# Altium schematic sheet usb_uart.SchDoc(usb_uart)
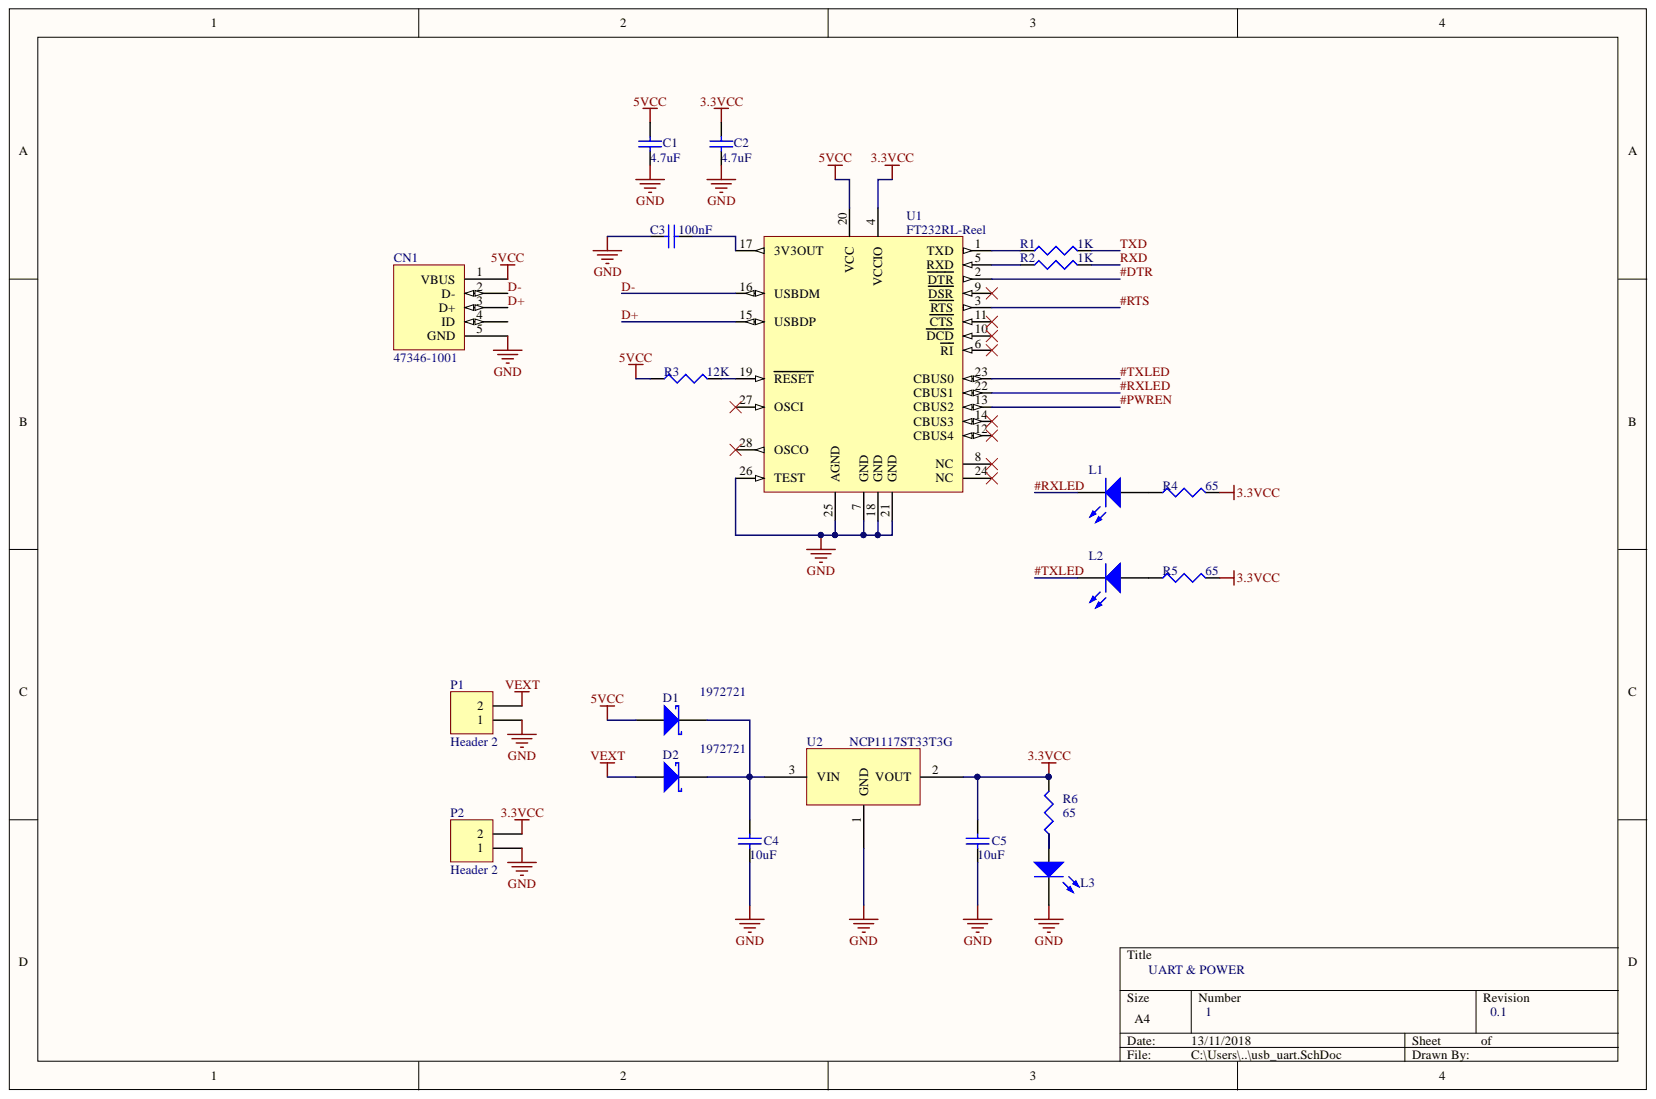
[diagram p0: usb_uart.SchDoc]

## Parts
C1  value 4.7uF  [C1206]  (hidden comment: Cap Semi)
C2  value 4.7uF  [C1206]  (hidden comment: Cap Semi)
C3  value 100nF  [C1206]  (hidden comment: Cap Semi)
C4  value 10uF  [C1206]  (hidden comment: Cap Semi)
C5  value 10uF  [C1206]  (hidden comment: Cap Semi)
CN1  47346-1001  [47346-1001]
D1  Supplier Part Number 1: 1972721  [SOD-128]  (hidden comment: PMEG3020DEP,115)
D2  Supplier Part Number 1: 1972721  [SOD-128]  (hidden comment: PMEG3020DEP,115)
L1  KM-23  [SOT-23]  (value not drawn)
L2  KM-23  [SOT-23]  (value not drawn)
L3  KM-23  [SOT-23]  (value not drawn)
P1  Header 2  [HDR1X2]
P2  Header 2  [HDR1X2]
R1  value 1K  [J1-0603]  (hidden comment: Res3)
R2  value 1K  [J1-0603]  (hidden comment: Res3)
R3  value 12K  [J1-0603]  (hidden comment: Res3)
R4  value 65  [J1-0603]  (hidden comment: Res3)
R5  value 65  [J1-0603]  (hidden comment: Res3)
R6  value 65  [J1-0603]  (hidden comment: Res3)
U1  FT232RL-Reel  [SSOP-28_N]
U2  NCP1117ST33T3G  [SOT-223-3]

## Nets
3.3VCC: C2.2 C5.1 P2.2 R4.2 R5.2 R6.2 U1.4(VCCIO) U2.2
5VCC: C1.2 CN1.1(VBUS) D1.2 R3.1 U1.20(VCC)
GND: C1.1 C2.1 C3.1 C4.1 C5.2 CN1.5(GND) L3.3 P1.1 P2.1 U1.7(GND) U1.18(GND) U1.21(GND) U1.25(AGND) U1.26(TEST) U2.1
VEXT: D2.2 P1.2
#DTR: U1.2(DTR)
#PWREN: U1.13(CBUS2)
#RTS: U1.3(RTS)
#RXLED: L1.3 U1.22(CBUS1)
#TXLED: L2.3 U1.23(CBUS0)
D+: CN1.3(D+) U1.15(USBDP)
D-: CN1.2(D-) U1.16(USBDM)
RXD: R2.2
TXD: R1.2
NetC3_2: C3.2 U1.17(3V3OUT)
NetC4_2: C4.2 D1.1 D2.1 U2.3
NetL1_1: L1.1 R4.1
NetL2_1: L2.1 R5.1
NetL3_1: L3.1 R6.1
NetR1_1: R1.1 U1.1(TXD)
NetR2_1: R2.1 U1.5(RXD)
NetR3_2: R3.2 U1.19(RESET)

Not connected: CN1 4(ID); U1 6(RI) 8(NC) 9(DSR) 10(DCD) 11(CTS) 12(CBUS4) 14(CBUS3) 24(NC) 27(OSCI) 28(OSCO)

## Library data of the parts
C1-C5 = Cap Semi: Capacitor (Semiconductor SIM Model); Simulation: CAP; Signal Integrity: Cap Semi; Published: 8-Jun-2000; PackageReference: C1206; PackageDescription: Chip Capacitor; Library Name: Miscellaneous Devices.IntLib; LatestRevisionNote: IPC-7351 Footprint Added.; LatestRevisionDate: 29-May-2009; Component Kind: Standard; Publisher: Altium Limited
CN1 = 47346-1001: MOLEX - 47346-1001 - MULTIPOLE CONNECTOR Conector USB, Micro USB Tipo B, USB 2.0 Montaje Superficial,; Pitch: 0.65mm; Number of Circuits: 5; Termination: Solder; Supplier 1: Farnell; Stock 1: 336; REACH SVHC: No SVHC; Pricing 1: 1=0.624, 60=0.6, 200=0.556, 500=0.532, 1000=0.503 (EUR); Orientation: Right Angle; Mount: Surface Mount; Min Operating Temperature: -30°C; Max Operating Temperature: 85°C; Manufacturer Part Number: 47346-1001; Manufacturer: Molex; Length: 7.8mm; Housing Material: Polymer; Halogen Free: Low Halogen; Contact Plating: Gold; Contact Material: Copper Alloy; ComponentLink1URL: https://es.farnell.com/molex/47346-1001/conector-micro-usb-tipo-b-hembra/dp/2782045?st=Conector%20USB,%20Micro%20USB%20Tipo%20B; ComponentLink1Description: Farnell; Component Kind: Standard; Library Name: ecubelib.SchLib
D1 D2 = PMEG3020DEP,115: NEXPERIA - Rectificador Schottky, 30 V, 2 A; Manufacturer: NXP Semiconductors; Peak Reverse Current: 50uA; Peak Non-Repetitive Surge Current: 50A; Max Reverse Voltage (DC): 30V; Max Power Dissipation: 625mW; Forward Voltage: 520mV; Forward Current: 2A; Element Configuration: Single; Supplier 1: Farnell; Stock 1: 4; Radiation Hardening: No; Pricing 1: 5=0.351, 25=0.27, 100=0.189, 250=0.167, 500=0.145, 1000=0.123, 5000=0.106 (EUR); Packaging: Tape and Reel; Package Quantity: 3000; Number of Pins: 2; Mount: Surface Mount; Min Operating Temperature: -55°C; Max Surge Current: 50A; Max Reverse Leakage Current: 50uA; Max Repetitive Reverse Voltage (Vrrm): 30V; Max Operating Temperature: 150°C; Manufacturer Part Number: PMEG3020DEP,115; Lead Free: Lead Free; ComponentLink3URL: http://www.nxp.com/documents/data_sheet/PMEG3020DEP.pdf; ComponentLink3Description: http://www.nxp.com/documents/data_sheet/PMEG3020DEP.pdf; ComponentLink2URL: http://www.nxp.com/documents/data_sheet/PMEG3020DEP.pdf?ecmp=mouser; ComponentLink2Description: http://www.nxp.com/documents/data_sheet/PMEG3020DEP.pdf?ecmp=mouser; ComponentLink1URL: https://es.farnell.com/nexperia/pmeg3020dep-115/diodo-schott-rect-30v-2a-sod128/dp/1972721?st=PMEG3020DEP,115; ComponentLink1Description: farnell; Component Kind: Standard; Average Rectified Current: 2A; Library Name: ecubelib.SchLib
L1-L3 = KM-23: Kingbright - LED, SOT-23; Manufacturer Part Number: KM-23ID-F; Wavelength: 625nm; Viewing Angle: 140°; Number of LEDs: 1; Luminous Intensity: 12mcd; Lens Style: Colored,Diffused,Rectangular; Lens Colour: Clear; Forward Voltage: 2V; Forward Current: 20mA; Colour: Red; Width: 1.2mm; Supplier 1: Farnell; Stock 1: 10839; REACH SVHC: No SVHC; Radiation Hardening: No; Pricing 1: 5=0.118, 25=0.112, 100=0.106, 250=0.101, 500=0.0968, 1000=0.0928 (GBP); Number of Pins: 3; Mount: Surface Mount; Manufacturer: Kingbright; Length: 2.8mm; Height: 1mm; Dominant Wavelength: 625nm; ComponentLink7URL: http://www.produktinfo.conrad.com/datenblaetter/125000-149999/144223-da-01-en-SMD_Leuchtdioden_KM23ID_Sot23_rot.pdf; ComponentLink7Description: http://www.produktinfo.conrad.com/datenblaetter/125000-149999/144223-da-01-en-SMD_Leuchtdioden_KM23ID_Sot23_rot.pdf; ComponentLink6URL: http://www.produktinfo.conrad.com/datenblaetter/175000-199999/180090-da-01-en-LED_SOT23_TYP_KM_23ID_F.pdf; ComponentLink6Description: http://www.produktinfo.conrad.com/datenblaetter/175000-199999/180090-da-01-en-LED_SOT23_TYP_KM_23ID_F.pdf; ComponentLink5URL: http://uk.rs-online.com/webdocs/032f/0900766b8032f166.pdf; ComponentLink5Description: http://uk.rs-online.com/webdocs/032f/0900766b8032f166.pdf; ComponentLink4URL: https://www.distrelec.biz/Web/Downloads/d_/en/cuKingbright_SOT-23_SMT-LED_EN.pdf?mime=application%2Fpdf; ComponentLink4Description: https://www.distrelec.biz/Web/Downloads/d_/en/cuKingbright_SOT-23_SMT-LED_EN.pdf?mime=application%2Fpdf; ComponentLink3URL: http://www.farnell.com/datasheets/1563685.pdf; ComponentLink3Description: http://www.farnell.com/datasheets/1563685.pdf; ComponentLink2URL: https://es.farnell.com/kingbright/km-23sgd-f/led-green-sot-23-smd/dp/2099217?st=KM-23; ComponentLink2Description: farnell-Green; ComponentLink1URL: https://es.farnell.com/kingbright/km-23id-f/led-red-sot-23-smd/dp/2099216?st=KM-23ID; ComponentLink1Description: farnell -RED; Component Kind: Standard; Case/Package: SOT-23; Library Name: ecubelib.SchLib
P1 P2 = Header 2: Header, 2-Pin; Signal Integrity: Connector; Library Name: Miscellaneous Connectors.IntLib; LatestRevisionNote: Re-released for DXP Platform.; LatestRevisionDate: 17-Jul-2002; Component Kind: Standard; Publisher: Altium Limited
R1-R6 = Res3: Resistor; Simulation: RESISTOR; Signal Integrity: Res; Published: 8-Jun-2000; PackageReference: J1-0603; PackageDescription: Chip Resistor; Library Name: Miscellaneous Devices.IntLib; LatestRevisionNote: IPC-7351 Footprint Added.; LatestRevisionDate: 29-May-2009; Component Kind: Standard; Publisher: Altium Limited
U1 = FT232RL-Reel: USB UART Asynchronous Serial Data Transfer Chip, SSOP-28, Tape and Reel; Published: 19-Aug-2010; PackageVersion: 2010; PackageReference: SSOP-28; PackageDescription: 28-Pin Small Outline Package 0.65 mm Pitch; Library Name: FTDI Communication UART.IntLib; DatasheetVersion: ver 2.07, Jul-2010; ComponentLink2URL: http://www.ftdichip.com/Support/Documents/DataSheets/ICs/DS_FT232R.pdf; ComponentLink2Description: Datasheet; ComponentLink1URL: http://www.ftdichip.com/Products/ICs/FT232R.htm; ComponentLink1Description: Manufacturer Link; Component Kind: Standard; Publisher: Altium Limited
U2 = NCP1117ST33T3G: ON SEMICONDUCTOR  - Regulador de Tensión Fija LDO 3.3V 1A; Reference Voltage: 1.25V; Quiescent Current: 10mA; Polarity: Positive; Output Voltage Accuracy: 1%; Output Type: Fixed; Number of Regulators: 1; Min Supply Voltage: 4.75V; Min Input Voltage: 3.5V; Max Supply Voltage: 15V; Max Input Voltage: 20V; Input Bias Current: 6mA; Dropout Voltage: 1.07V; Width: 3.7mm; Termination: SMD/SMT; Supplier 1: Farnell; Stock 1: 0; REACH SVHC: No SVHC; Radiation Hardening: No; Pricing 1: 150=0.196, 250=0.174, 500=0.151, 1000=0.129, 5000=0.121, 10000=0.116 (EUR); Packaging: Tape and Reel; Package Quantity: 1; Output Voltage: 3.3V; Output Current: 1A; Number of Pins: 3; Number of Outputs: 1; Nominal Output Voltage: 3.3V; Mount: Surface Mount; Min Output Voltage: 3.3V; Min Operating Temperature: 0°C; Min Current Limit: 1A; Max Output Voltage: 3.3V; Max Output Current: 1A; Max Operating Temperature: 125°C; Manufacturer Part Number: NCP1117ST33T3G; Manufacturer: ON Semiconductor; Length: 6.7mm; Lead Free: Lead Free; Height: 1.69mm; Halogen Free: Halogen Free; Contact Plating: Matte,Tin; ComponentLink7URL: http://www.onsemi.com/pub_link/Collateral/NCP1117-D.PDF; ComponentLink7Description: http://www.onsemi.com/pub_link/Collateral/NCP1117-D.PDF; ComponentLink6URL: http://www.farnell.com/datasheets/1748260.pdf; ComponentLink6Description: http://www.farnell.com/datasheets/1748260.pdf; ComponentLink5URL: https://www.verical.com/server-webapp/html/getDatasheet?partID=82718; ComponentLink5Description: https://www.verical.com/server-webapp/html/getDatasheet?partID=82718; ComponentLink4URL: http://www.onsemi.com/pub/Collateral/NCP1117-D.PDF; ComponentLink4Description: http://www.onsemi.com/pub/Collateral/NCP1117-D.PDF; ComponentLink3URL: http://www.farnell.com/datasheets/1726422.pdf; ComponentLink3Description: http://www.farnell.com/datasheets/1726422.pdf; ComponentLink2URL: http://www.onsemi.ru.com/pub/Collateral/NCP1117-D.PDF; ComponentLink2Description: http://www.onsemi.ru.com/pub/Collateral/NCP1117-D.PDF; ComponentLink1URL: https://es.farnell.com/on-semiconductor/ncp1117st33t3g/ic-linear-voltage-regulator/dp/1652366?st=NCP1117ST33T3G; ComponentLink1Description: farnell; Component Kind: Standard; Case/Package: SOT-223; Accuracy: 1%; Library Name: ecubelib.SchLib
